# Altium schematic sheet 2_usb_debug.SchDoc(2_usb_debug)
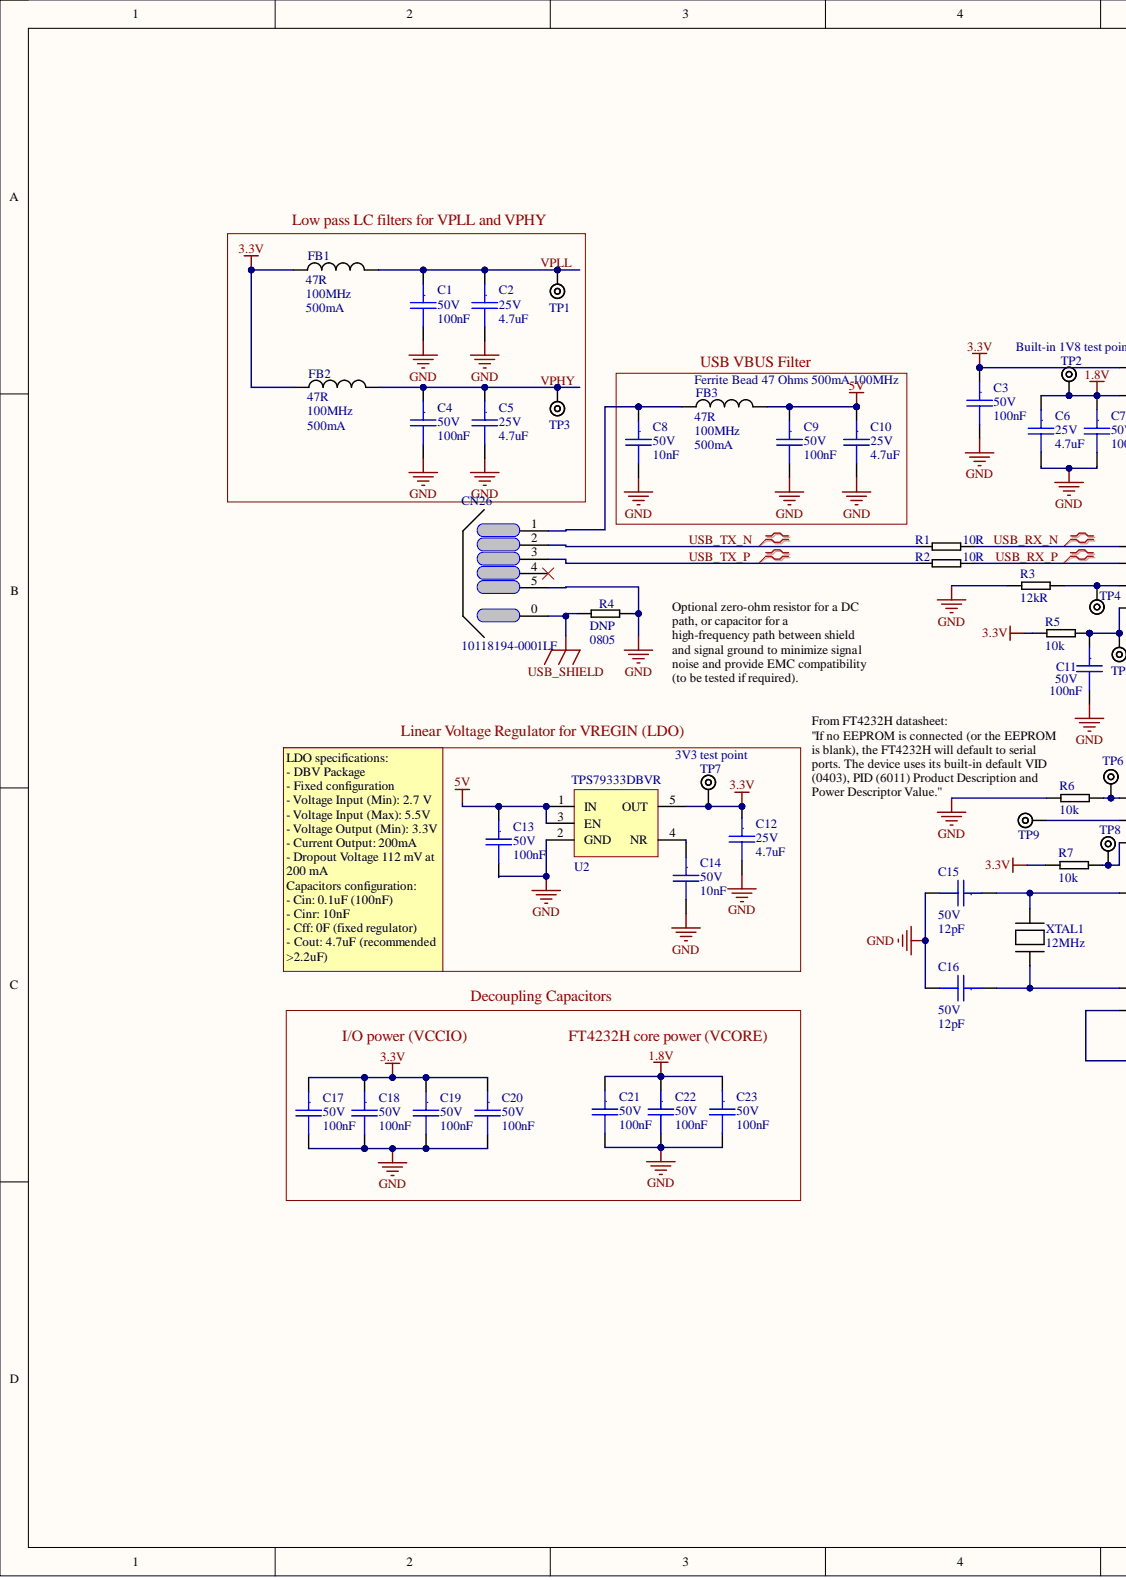
[diagram p0: 2_usb_debug.SchDoc, left]
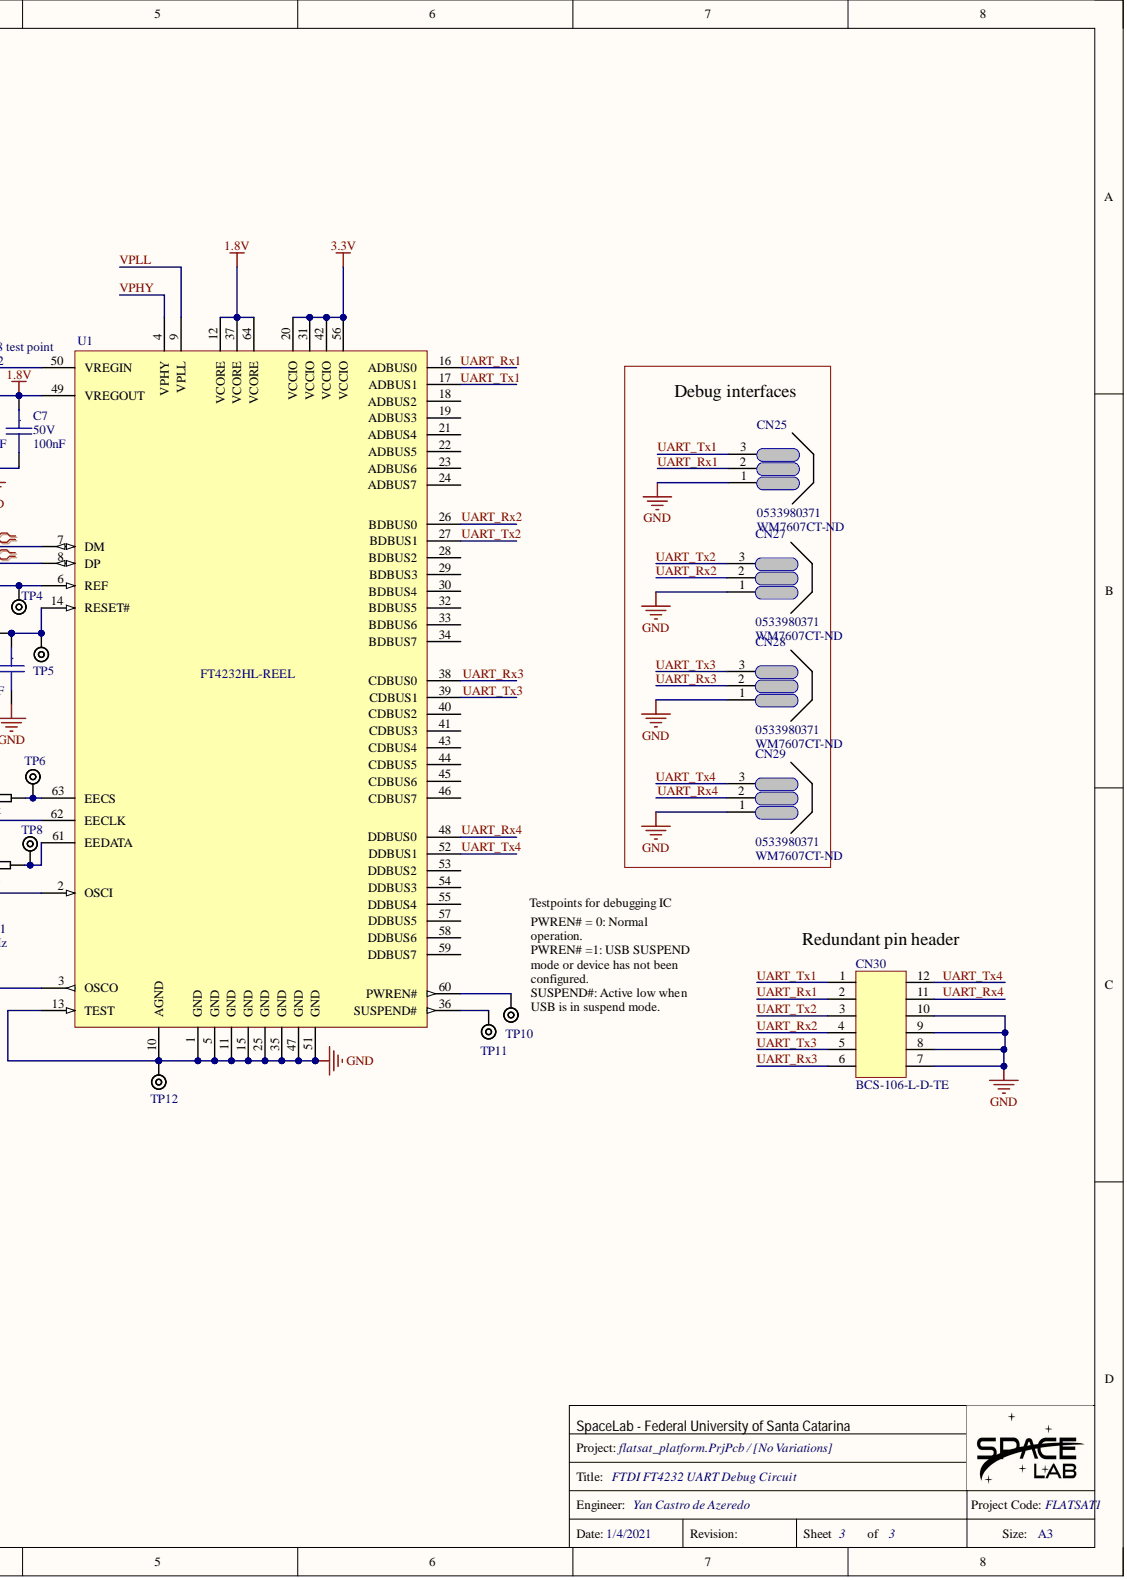
[diagram p1: 2_usb_debug.SchDoc, right]

## Parts
C1  Capacitance: 100nF  Voltage: 50V  [C0603_MD]  (hidden comment: 0.1 uF, 0603 (1608 metric))
C2  Capacitance: 4.7uF  Voltage: 25V  [C0805_MD]  (hidden comment: 4.7 uF, 0802 (2012 metric))
C3  Capacitance: 100nF  Voltage: 50V  [C0603_MD]  (hidden comment: 0.1 uF, 0603 (1608 metric))
C4  Capacitance: 100nF  Voltage: 50V  [C0603_MD]  (hidden comment: 0.1 uF, 0603 (1608 metric))
C5  Capacitance: 4.7uF  Voltage: 25V  [C0805_MD]  (hidden comment: 4.7 uF, 0802 (2012 metric))
C6  Capacitance: 4.7uF  Voltage: 25V  [C0805_MD]  (hidden comment: 4.7 uF, 0802 (2012 metric))
C7  Capacitance: 100nF  Voltage: 50V  [C0603_MD]  (hidden comment: 0.1 uF, 0603 (1608 metric))
C8  Capacitance: 10nF  Voltage: 50V  [C0805_MD]  (hidden comment: 10000 pF, 0805 (2012 metric))
C9  Capacitance: 100nF  Voltage: 50V  [C0603_MD]  (hidden comment: 0.1 uF, 0603 (1608 metric))
C10  Capacitance: 4.7uF  Voltage: 25V  [C0805_MD]  (hidden comment: 4.7 uF, 0802 (2012 metric))
C11  Capacitance: 100nF  Voltage: 50V  [C0603_MD]  (hidden comment: 0.1 uF, 0603 (1608 metric))
C12  Capacitance: 4.7uF  Voltage: 25V  [C0805_MD]  (hidden comment: 4.7 uF, 0802 (2012 metric))
C13  Capacitance: 100nF  Voltage: 50V  [C0603_MD]  (hidden comment: 0.1 uF, 0603 (1608 metric))
C14  Capacitance: 10nF  Voltage: 50V  [C0805_MD]  (hidden comment: 10000 pF, 0805 (2012 metric))
C15  Capacitance: 12pF  Voltage: 50V  [C0603_MD]  (hidden comment: 12 pF, 0603 (1608 metric))
C16  Capacitance: 12pF  Voltage: 50V  [C0603_MD]  (hidden comment: 12 pF, 0603 (1608 metric))
C17  Capacitance: 100nF  Voltage: 50V  [C0603_MD]  (hidden comment: 0.1 uF, 0603 (1608 metric))
C18  Capacitance: 100nF  Voltage: 50V  [C0603_MD]  (hidden comment: 0.1 uF, 0603 (1608 metric))
C19  Capacitance: 100nF  Voltage: 50V  [C0603_MD]  (hidden comment: 0.1 uF, 0603 (1608 metric))
C20  Capacitance: 100nF  Voltage: 50V  [C0603_MD]  (hidden comment: 0.1 uF, 0603 (1608 metric))
C21  Capacitance: 100nF  Voltage: 50V  [C0603_MD]  (hidden comment: 0.1 uF, 0603 (1608 metric))
C22  Capacitance: 100nF  Voltage: 50V  [C0603_MD]  (hidden comment: 0.1 uF, 0603 (1608 metric))
C23  Capacitance: 100nF  Voltage: 50V  [C0603_MD]  (hidden comment: 0.1 uF, 0603 (1608 metric))
CN25  0533980371  [PICO BLADE 0533980371]
CN26  10118194-0001LF  [MICRO USB B 90GRAUS]
CN27  0533980371  Supplier Part Number 1: WM7607CT-ND  [PICO BLADE 0533980371]
CN28  0533980371  Supplier Part Number 1: WM7607CT-ND  [PICO BLADE 0533980371]
CN29  0533980371  Supplier Part Number 1: WM7607CT-ND  [PICO BLADE 0533980371]
CN30  BCS-106-L-D-TE  [BCS-106-L-D-TE]
FB1  Current Rating: 500mA  Impedance: 47R  Test Frequency: 100MHz  [L0603]  (hidden comment: Ferrite Bead 47 Ohms 500mA 100MHz)
FB2  Current Rating: 500mA  Impedance: 47R  Test Frequency: 100MHz  [L0603]  (hidden comment: Ferrite Bead 47 Ohms 500mA 100MHz)
FB3  Ferrite Bead 47 Ohms 500mA 100MHz  Core Material: Ferrite  Current Rating: 500mA  Impedance: 47R  Test Frequency: 100MHz  [L0603]
R1  Resistance: 10R  [R0603_MD]  (hidden comment: RES 10 ohms (0603))
R2  Resistance: 10R  [R0603_MD]  (hidden comment: RES 10 ohms (0603))
R3  Resistance: 12kR  [R0805_MD]  (hidden comment: RES 12K ohms (0805))
R4  DNP  Case Code (Imperial): 0805  [R0805_MD]
R5  value 10k  [R0805_MD]  (hidden comment: 10k ohms, 0805 (2012 metric))
R6  value 10k  [R0805_MD]  (hidden comment: 10k ohms, 0805 (2012 metric))
R7  value 10k  [R0805_MD]  (hidden comment: 10k ohms, 0805 (2012 metric))
TP1  DNP  [TEST POINT PTH]  (value not drawn)  (no BOM)
TP2  DNP  [TEST POINT PTH]  (value not drawn)  (no BOM)
TP3  DNP  [TEST POINT PTH]  (value not drawn)  (no BOM)
TP4  DNP  [TEST POINT PTH]  (value not drawn)  (no BOM)
TP5  DNP  [TEST POINT PTH]  (value not drawn)  (no BOM)
TP6  DNP  [TEST POINT PTH]  (value not drawn)  (no BOM)
TP7  DNP  [TEST POINT PTH]  (value not drawn)  (no BOM)
TP8  DNP  [TEST POINT PTH]  (value not drawn)  (no BOM)
TP9  DNP  [TEST POINT PTH]  (value not drawn)  (no BOM)
TP10  DNP  [TEST POINT PTH]  (value not drawn)  (no BOM)
TP11  DNP  [TEST POINT PTH]  (value not drawn)  (no BOM)
TP12  DNP  [TEST POINT PTH]  (value not drawn)  (no BOM)
U1  FT4232HL-REEL  [LQFP-64]
U2  TPS79333DBVR  [SOT23-5]
XTAL1  Frequency: 12MHz  [TXC 7A Series]  (hidden comment: 12.000MHz 12pF)

## Nets
1.8V: C6.1 C7.1 C21.1 C22.1 C23.1 TP2.1 U1.12(VCORE) U1.37(VCORE) U1.49(VREGOUT) U1.64(VCORE)
3.3V: C3.1 C12.1 C17.1 C18.1 C19.1 C20.1 FB1.1 FB2.1 R5.1 R7.1 TP7.1 U1.20(VCCIO) U1.31(VCCIO) U1.42(VCCIO) U1.50(VREGIN) U1.56(VCCIO) U2.5(OUT)
5V: C9.1 C10.1 C13.1 FB3.2 U2.1(IN) U2.3(EN)
GND: C1.2 C2.2 C3.2 C4.2 C5.2 C6.2 C7.2 C8.2 C9.2 C10.2 C11.2 C12.2 C13.2 C14.2 C15.2 C16.2 C17.2 C18.2 C19.2 C20.2 C21.2 C22.2 C23.2 CN25.1 CN26.5 CN27.1 CN28.1 CN29.1 CN30.8 CN30.9 CN30.10 R3.1 R4.2 R6.1 TP12.1 U1.1(GND) U1.5(GND) U1.10(AGND) U1.11(GND) U1.13(TEST) U1.15(GND) U1.25(GND) U1.35(GND) U1.47(GND) U1.51(GND) U2.2(GND)
USB_SHIELD: CN26.0 R4.1
UART_Rx1: CN25.2 CN30.2 U1.16(ADBUS0)
UART_Rx2: CN27.2 CN30.4 U1.26(BDBUS0)
UART_Rx3: CN28.2 CN30.6 U1.38(CDBUS0)
UART_Rx4: CN29.2 CN30.11 U1.48(DDBUS0)
UART_Tx1: CN25.3 CN30.1 U1.17(ADBUS1)
UART_Tx2: CN27.3 CN30.3 U1.27(BDBUS1)
UART_Tx3: CN28.3 CN30.5 U1.39(CDBUS1)
UART_Tx4: CN29.3 CN30.12 U1.52(DDBUS1)
USB_RX_N: R1.2 U1.7(DM)
USB_TX_N: CN26.2 R1.1
USB_TX_P: CN26.3 R2.1
VPHY: C4.1 C5.1 FB2.2 TP3.1 U1.4(VPHY)
VPLL: C1.1 C2.1 FB1.2 TP1.1 U1.9(VPLL)
NetC8_1: C8.1 CN26.1 FB3.1
NetC11_1: C11.1 R5.2 TP5.1 U1.14(RESET#)
NetC14_1: C14.1 U2.4(NR)
NetC15_1: C15.1 U1.2(OSCI) XTAL1.1
NetC16_1: C16.1 U1.3(OSCO) XTAL1.2
NetR2_2: R2.2 U1.8(DP)
NetR3_2: R3.2 TP4.1 U1.6(REF)
NetR6_2: R6.2 TP6.1 U1.63(EECS)
NetR7_2: R7.2 TP8.1 U1.61(EEDATA)
NetTP9_1: TP9.1 U1.62(EECLK)
NetTP10_1: TP10.1 U1.60(PWREN#)
NetTP11_1: TP11.1 U1.36(SUSPEND#)
USB_RX_P:

Not connected: CN26 4; CN30 7; U1 18(ADBUS2) 19(ADBUS3) 21(ADBUS4) 22(ADBUS5) 23(ADBUS6) 24(ADBUS7) 28(BDBUS2) 29(BDBUS3) 30(BDBUS4) 32(BDBUS5) 33(BDBUS6) 34(BDBUS7) 40(CDBUS2) 41(CDBUS3) 43(CDBUS4) 44(CDBUS5) 45(CDBUS6) 46(CDBUS7) 53(DDBUS2) 54(DDBUS3) 55(DDBUS4) 57(DDBUS5) 58(DDBUS6) 59(DDBUS7)

## Library data of the parts
C1 C3 C4 C7 C9 C11 C13 C17-C23 = C1608X7R1H104K080AA: TDK - C1608X7R1H104K080AA - SMD Multilayer Ceramic Capacitor, 0.1 µF, 50 V, 0603 [1608 Metric], ± 10%, X7R, C Series; Case Code (Imperial): 0603; Case Code (Metric): 1608; Case/Package: 0603; Component Kind: Standard; ComponentLink1Description: https://product.tdk.com/info/en/catalog/spec/mlccspec_commercial_general_midvoltage_en.pdf?src-supplier=Digi-Key; ComponentLink1URL: https://product.tdk.com/info/en/catalog/spec/mlccspec_commercial_general_midvoltage_en.pdf?src-supplier=Digi-Key; ComponentLink2Description: http://uk.rs-online.com/webdocs/13d1/0900766b813d1103.pdf?src-supplier=RSComponents; ComponentLink2URL: http://uk.rs-online.com/webdocs/13d1/0900766b813d1103.pdf?src-supplier=RSComponents; ComponentLink3Description: http://www.tdk.co.jp/tefe02/e412_c.pdf?src-supplier=Avnet+Europe; ComponentLink3URL: http://www.tdk.co.jp/tefe02/e412_c.pdf?src-supplier=Avnet+Europe; ComponentLink4Description: http://www.farnell.com/datasheets/2792994.pdf?src-supplier=Farnell; ComponentLink4URL: http://www.farnell.com/datasheets/2792994.pdf?src-supplier=Farnell; ComponentLink5Description: http://www.farnell.com/datasheets/1744655.pdf?src-supplier=Element14; ComponentLink5URL: http://www.farnell.com/datasheets/1744655.pdf?src-supplier=Element14; Composition: Ceramic; Depth: 0.8mm; Dielectric: X7R; Dielectric Material: Ceramic; Height: 0.8mm; Lead Free: Lead Free; Length: 1.6mm; Manufacturer: TDK; Manufacturer Part Number: C1608X7R1H104K080AA; Max Operating Temperature: 125°C; Min Operating Temperature: -55°C; Mount: Surface Mount; Number of Pins: 2; Package Quantity: 1; Packaging: Tape and Reel; Pricing 1: 1=0.12, 10=0.088, 100=0.0413, 500=0.02896, 1000=0.02397 (USD); Pricing 2: 1=0.12, 10=0.088, 100=0.041, 500=0.029, 1000=0.024, 4000=0.017, 8000=0.016, 24000=0.013, 48000=0.011 (USD); Radiation Hardening: No; REACH SVHC: No SVHC; Stock 1: 513759; Stock 2: 34512; Supplier 1: Digi-Key; Supplier 2: Mouser; Supplier Part Number 1: 445-1314-1-ND; Supplier Part Number 2: 810-C1608X7R1H104K; Termination: SMD/SMT; Thickness: 0.037inch; Tolerance: 10%; Voltage Rating: 50V; Voltage Rating (DC): 50V; Weight: 7.1E-05oz; Width: 0.8mm; Library Name: flatsat_platform.SchLib
C2 C5 C6 C10 C12 = C2012X7R1E475K125AB: Ceramic Capacitor SMD Multilayer, 0805 [2012 Metric], 4.7 uF, 25 V,  10%, X7R, C Series; Manufacturer: TDK; Case Code (Imperial): 0805; Case Code (Metric): 2012; Case/Package: 0805; Component Kind: Standard; ComponentLink1Description: http://www.mouser.com/ds/2/400/lcc_commercial_general_en-837201.pdf?src-supplier=Mouser; ComponentLink1URL: http://www.mouser.com/ds/2/400/lcc_commercial_general_en-837201.pdf?src-supplier=Mouser; ComponentLink2Description: https://product.tdk.com/info/en/catalog/spec/mlccspec_commercial_general_midvoltage_en.pdf?src-supplier=Digi-Key; ComponentLink2URL: https://product.tdk.com/info/en/catalog/spec/mlccspec_commercial_general_midvoltage_en.pdf?src-supplier=Digi-Key; ComponentLink3Description: http://www.farnell.com/datasheets/1796654.pdf?src-supplier=Newark; ComponentLink3URL: http://www.farnell.com/datasheets/1796654.pdf?src-supplier=Newark; ComponentLink4Description: http://www.tdk.co.jp/tefe02/e412_c.pdf?src-supplier=Avnet+Europe; ComponentLink4URL: http://www.tdk.co.jp/tefe02/e412_c.pdf?src-supplier=Avnet+Europe; Composition: Ceramic; Depth: 1.25mm; Dielectric: X7R; Dielectric Material: Ceramic; Height: 1.25mm; Lead Free: Lead Free; Length: 2mm; Manufacturer Part Number: C2012X7R1E475K125AB; Max Operating Temperature: 125°C; Min Operating Temperature: -55°C; Mount: Surface Mount; Package Quantity: 2000; Packaging: Tape and Reel; Pricing 1: 1=0.48, 10=0.339, 100=0.223, 500=0.16518, 1000=0.1404 (USD); Pricing 2: 1=0.54, 10=0.382, 100=0.251, 500=0.186, 1000=0.158, 2000=0.121 (USD); Radiation Hardening: No; REACH SVHC: No SVHC; Stock 1: 196988; Stock 2: 141636; Supplier 1: Digi-Key; Supplier 2: Mouser; Supplier Part Number 1: 445-5972-1-ND; Supplier Part Number 2: 810-C2012X7R1E475K; Termination: SMD/SMT; Thickness: 0.057inch; Tolerance: 10%; Voltage Rating: 25V; Voltage Rating (DC): 25V; Weight: 0.000194oz; Width: 0.049inch; Library Name: flatsat_platform.SchLib
C8 C14 = 08055C103KAT4A: AVX - 08055C103KAT4A - SMD Multilayer Ceramic Capacitor, 10000 pF, 50 V, 0805 [2012 Metric], &#177; 10%, X7R; Manufacturer: Kyocera AVX; Case Code (Imperial): 0805; Case Code (Metric): 2012; Case/Package: 0805; Component Kind: Standard; ComponentLink1Description: http://www.mouser.com/ds/2/40/X7RDielectric-777024.pdf?src-supplier=Mouser; ComponentLink1URL: http://www.mouser.com/ds/2/40/X7RDielectric-777024.pdf?src-supplier=Mouser; ComponentLink2Description: http://www.avx.com/docs/Catalogs/cx7r.pdf?src-supplier=Future+Electronics; ComponentLink2URL: http://www.avx.com/docs/Catalogs/cx7r.pdf?src-supplier=Future+Electronics; Depth: 1.25mm; Dielectric: X7R; Height: 0.94mm; Lead Free: Lead Free; Length: 2.01mm; Manufacturer Part Number: 08055C103KAT4A; Max Operating Temperature: 125°C; Min Operating Temperature: -55°C; Mount: Surface Mount; Number of Pins: 2; Packaging: Tape and Reel; Pricing 1: 1=0.13, 10=0.094, 100=0.044, 500=0.03086, 1000=0.02555, 2500=0.02378, 5000=0.02176 (USD); Pricing 2: 1=0.12, 10=0.085, 100=0.04, 500=0.028, 1000=0.023, 2500=0.018 (USD); Radiation Hardening: No; Stock 1: 515489; Stock 2: 88428; Supplier 1: Digi-Key; Supplier 2: Mouser; Supplier Part Number 1: 478-10687-1-ND; Supplier Part Number 2: 581-08055C103KAT4A; Termination: SMD/SMT; Tolerance: 10%; Voltage Rating: 50V; Voltage Rating (DC): 50V; Weight: 0.000194oz; Width: 1.25mm; Library Name: flatsat_platform.SchLib
C15 C16 = CL10C120JB8NNNC: Cap Ceramic 12pF 50VDC C0G 5% SMD 0603 Paper T/R; Manufacturer: Samsung; Temperature Coefficient: 300ppm/°C; Case Code (Imperial): 0603; Case Code (Metric): 1608; Case/Package: 0603; Component Kind: Standard; ComponentLink1Description: https://media.digikey.com/pdf/Data%20Sheets/Samsung%20PDFs/CL_Series_MLCC_ds.pdf?src-supplier=Digi-Key; ComponentLink1URL: https://media.digikey.com/pdf/Data%20Sheets/Samsung%20PDFs/CL_Series_MLCC_ds.pdf?src-supplier=Digi-Key; ComponentLink2Description: https://www.verical.com/server-webapp/html/getDatasheet?partID=640658&src-supplier=Verical; ComponentLink2URL: https://www.verical.com/server-webapp/html/getDatasheet?partID=640658&src-supplier=Verical; ComponentLink3Description: https://produktinfo.conrad.com/datenblaetter/450000-474999/457317-da-01-en-VS_KONDENSATOR_0603.pdf?src-supplier=Conrad; ComponentLink3URL: https://produktinfo.conrad.com/datenblaetter/450000-474999/457317-da-01-en-VS_KONDENSATOR_0603.pdf?src-supplier=Conrad; Contact Plating: Tin; Depth: 0.8mm; Dielectric: C0G; Height: 0.8mm; Lead Free: Lead Free; Length: 1.6mm; Manufacturer Part Number: CL10C120JB8NNNC; Material: Ceramic; Max Operating Temperature: 125°C; Min Operating Temperature: -55°C; Mount: Surface Mount; Package Quantity: 1; Packaging: Tape and Reel; Pricing 1: 1=0.1, 10=0.045, 100=0.0201, 500=0.01436, 1000=0.01128 (USD); Radiation Hardening: No; Stock 1: 64675; Supplier 1: Digi-Key; Supplier Part Number 1: 1276-1254-1-ND; Thickness: 0.035inch; Tolerance: 5%; Voltage Rating: 50V; Voltage Rating (DC): 50V; Width: 0.031inch; Library Name: flatsat_platform.SchLib
CN25 CN27-CN29 = 0533980371: Conn Wire to Board HDR 3 POS 1.25mm Solder ST SMD Embossed T/R; Manufacturer: Molex; Manufacturer Part Number: 53398-0371; Body Plating: Tin; Contact Resistance: 20mR; Current Rating: 1A; Gender: Male; Glow Wire Compliant: Non-compliant; Insulation Resistance: 100MR; N of Positions: 3; Voltage Rating: 125V; Category: Headers and Wire Housings; Color: Natural; Component Kind: Standard; ComponentLink1Description: https://datasheet.ciiva.com/30064/225330533980371-pcb-headers-30064544.pdf?src-supplier=Onlinecomponents.com; ComponentLink1URL: https://datasheet.ciiva.com/30064/225330533980371-pcb-headers-30064544.pdf?src-supplier=Onlinecomponents.com; ComponentLink2Description: https://datasheet.ciiva.com/9468/0533980371-pcb-headers-9468515.pdf?src-supplier=Avnet; ComponentLink2URL: https://datasheet.ciiva.com/9468/0533980371-pcb-headers-9468515.pdf?src-supplier=Avnet; ComponentLink3Description: https://datasheet.ciiva.com/11748/0900766b8078fc93-11748675.pdf?src-supplier=RSComponents; ComponentLink3URL: https://datasheet.ciiva.com/11748/0900766b8078fc93-11748675.pdf?src-supplier=RSComponents; ComponentLink4Description: https://datasheet.ciiva.com/19465/1302182-19465258.pdf?src-supplier=Jameco; ComponentLink4URL: https://datasheet.ciiva.com/19465/1302182-19465258.pdf?src-supplier=Jameco; ComponentLink5Description: https://datasheet.ciiva.com/5147/0533980371-pcb-headers-146663-5147630.pdf?src-supplier=Mouser; ComponentLink5URL: https://datasheet.ciiva.com/5147/0533980371-pcb-headers-146663-5147630.pdf?src-supplier=Mouser; ComponentLink6Description: https://datasheet.ciiva.com/16972/70091287-16972277.pdf?src-supplier=Allied+Electronics; ComponentLink6URL: https://datasheet.ciiva.com/16972/70091287-16972277.pdf?src-supplier=Allied+Electronics; ComponentLink7Description: https://datasheet.ciiva.com/7810/1788579-7810395.pdf?src-supplier=Element14; ComponentLink7URL: https://datasheet.ciiva.com/7810/1788579-7810395.pdf?src-supplier=Element14; ComponentLink8Description: https://datasheet.ciiva.com/16496/0533980371-pcb-headers-16496972.pdf?src-supplier=Avnet+Asia; ComponentLink8URL: https://datasheet.ciiva.com/16496/0533980371-pcb-headers-16496972.pdf?src-supplier=Avnet+Asia; ComponentLink9Description: https://datasheet.ciiva.com/16658/0533980371-pcb-headers-16658430.pdf?src-supplier=Avnet+Europe; ComponentLink9URL: https://datasheet.ciiva.com/16658/0533980371-pcb-headers-16658430.pdf?src-supplier=Avnet+Europe; Contact Gender: Male; Contact Material: Bronze,Tin; Contact Plating: Tin; Flammability Rating: UL94 V-0; Housing Color: Natural; Housing Material: Nylon; Lead Free: Lead Free; Lead Pitch: 1.25mm; Max Operating Temperature: 85°C; Min Operating Temperature: -40°C; Mount: Surface Mount; Mount Type: SMD; Number of Circuits: 3; Number of Contacts: 3; Number of Positions: 3; Number of Rows: 1; Orientation: Vertical; Package Quantity: 1000; Packaging: Tape and Reel; Pitch: 1.25mm; Pricing 1: 1=0.64000, 10=0.54400, 100=0.42320 (USD); Radiation Hardening: No; REACH SVHC: Unknown; RoHS: Yes; Stock 1: 192; Supplier 1: Digi-Key; Termination: SMD/SMT; Value: 0533980371; Voltage Rating (AC): 125V; Voltage Rating (DC): 125V; Working Voltage: 125V; Library Name: flatsat_platform.SchLib
  CN25: Supplier Part Number 1: WM7607CT-ND
CN26 = 10118194-0001LF: CONN USB MICRO B RECPT SMT R/A; Manufacturer: FCI; Connector Type: USB,Receptacle; Current Rating: 1.8A; Gender: Receptacle; Insulation Resistance: 1GR; Max Voltage Rating (AC): 100V; N of Positions: 5; Number of Ports: 1; Pitch: 0.65mm; Category: USB Connectors; Color: Black; Component Kind: Standard; ComponentLink1Description: https://datasheet.ciiva.com/4598/10118194ac-197217-4598807.pdf?src-supplier=Mouser; ComponentLink1URL: https://datasheet.ciiva.com/4598/10118194ac-197217-4598807.pdf?src-supplier=Mouser; ComponentLink2Description: https://datasheet.ciiva.com/26945/getdatasheetpartid-418619-26945695.pdf?src-supplier=Verical; ComponentLink2URL: https://datasheet.ciiva.com/26945/getdatasheetpartid-418619-26945695.pdf?src-supplier=Verical; ComponentLink3Description: https://datasheet.ciiva.com/851/10118194-851110.pdf?src-supplier=Digi-Key; ComponentLink3URL: https://datasheet.ciiva.com/851/10118194-851110.pdf?src-supplier=Digi-Key; ComponentLink4Description: https://datasheet.ciiva.com/851/10118194-851111.pdf?src-supplier=Digi-Key; ComponentLink4URL: https://datasheet.ciiva.com/851/10118194-851111.pdf?src-supplier=Digi-Key; Contact Material: Copper Alloy; Contact Plating: Gold; Depth: 5mm; Features: Shielded; Flammability Rating: UL94 V-0; Housing Material: Polymer; Lead Free: Lead Free; Length: 8.05mm; Manufacturer Part Number: 10118194-0001LF; Max Operating Temperature: 85°C; Min Operating Temperature: -55°C; Mount: Surface Mount,Horizontal; Mount Type: PTH; Number of Contacts: 5; Number of Terminals/Pins: 5; Orientation: Right Angle; Package Quantity: 9600; Packaging: Tape and Reel; Pricing 4: 1=0.22400, 25=0.22400, 100=0.22400, 250=0.20500, 500=0.20500 (USD); Radiation Hardening: No; RoHS: Yes; Stock 4: 39603; Supplier 1: Digi-Key; Supplier 2: Farnell; Supplier 3: Mouser; Supplier 4: Newark; Supplier Part Number 1: 609-4618-1-ND; Supplier Part Number 2: 1645325; Supplier Part Number 3: 649-10118194-0001LF; Supplier Part Number 4: 67T2260; Termination: Solder; Value: 10118194-0001LF; Library Name: flatsat_platform.SchLib
CN30 = BCS-106-L-D-TE: CONN RCPT 12POS 0.1 GOLD PCB; Manufacturer: Samtec; Connector Type: Receptacle; Contact Resistance: 10mR; Max Current Rating: 5.9A; Max Voltage Rating (AC): 475V; Number of Contacts: 12; Pitch: 2.54mm; Row Spacing: 0.1inch; Category: Connectors; Color: Black; Component Kind: Standard; ComponentLink1Description: https://datasheet.ciiva.com/16706/bcs-16706444.pdf?src-supplier=Avnet+Europe; ComponentLink1URL: https://datasheet.ciiva.com/16706/bcs-16706444.pdf?src-supplier=Avnet+Europe; ComponentLink2Description: https://datasheet.ciiva.com/413/bcs-1xx-xxx-x-xx-xxx-mkt-413758.pdf?src-supplier=Digi-Key; ComponentLink2URL: https://datasheet.ciiva.com/413/bcs-1xx-xxx-x-xx-xxx-mkt-413758.pdf?src-supplier=Digi-Key; Contact Material: Bronze; Contact Plating: Gold; Depth: 5.08mm; Gender: Receptacle; Housing Color: Black; Housing Material: Polymer; Lead Free: Lead Free; Length: 15.24mm; Manufacturer Part Number: BCS-106-L-D-TE; Max Operating Temperature: 125°C; Min Operating Temperature: -55°C; Mount: Through Hole; Number of Positions: 12; Number of Rows: 2; Orientation: Vertical; Packaging: Rail/Tube; Pricing 1: 1=1.77000, 10=1.60200, 25=1.50360, 50=1.43820, 100=1.37290, 250=1.24216, 500=1.14408 (USD); Radiation Hardening: No; REACH SVHC: No SVHC; RoHS: Yes; Stock 1: 7411; Supplier 1: Digi-Key; Supplier Part Number 1: SAM1008-06-ND; Termination: Solder; Width: 5.08mm; Library Name: flatsat_platform.SchLib
FB1-FB3 = 742792608: WURTH ELEKTRONIK   742792608   FERRITE BEAD, 0.1 OHM, 0.5A, 0603; Manufacturer: Wurth Electronics; DC Resistance (DCR): 100mR; Inductance: 1H; Number of Lines: 1; Tolerance: 25%; Case Code (Imperial): 0603; Case Code (Metric): 1608; Case/Package: SMD/SMT; Component Kind: Standard; ComponentLink1Description: http://katalog.we-online.de/pbs/datasheet/742792608.pdf?src-supplier=Digi-Key; ComponentLink1URL: http://katalog.we-online.de/pbs/datasheet/742792608.pdf?src-supplier=Digi-Key; ComponentLink2Description: http://www.farnell.com/datasheets/2290671.pdf?src-supplier=Farnell; ComponentLink2URL: http://www.farnell.com/datasheets/2290671.pdf?src-supplier=Farnell; ComponentLink3Description: http://documents.rs-components.com/techlib://itc_doclist_GB.htm?stockno=6693986&src-supplier=RSComponents; ComponentLink3URL: http://documents.rs-components.com/techlib://itc_doclist_GB.htm?stockno=6693986&src-supplier=RSComponents; ComponentLink4Description: http://www.farnell.com/datasheets/1696033.pdf?src-supplier=Element14; ComponentLink4URL: http://www.farnell.com/datasheets/1696033.pdf?src-supplier=Element14; ComponentLink5Description: http://www.mouser.com/ds/2/445/742792608-538481.pdf?src-supplier=Mouser; ComponentLink5URL: http://www.mouser.com/ds/2/445/742792608-538481.pdf?src-supplier=Mouser; ComponentLink6Description: http://www.farnell.com/datasheets/2290671.pdf?src-supplier=Newark; ComponentLink6URL: http://www.farnell.com/datasheets/2290671.pdf?src-supplier=Newark; Depth: 0.8mm; Height: 0.8mm; Lead Free: Lead Free; Length: 1.6mm; Manufacturer Part Number: 742792608; Material: Ferrite; Max Current Rating: 500mA; Max DC Current: 500mA; Max Operating Temperature: 125°C; Min Operating Temperature: -55°C; Mount: Surface Mount; Package Quantity: 1; Packaging: Tape and Reel; Pricing 1: 1=0.17, 50=0.168, 100=0.155, 500=0.148, 1000=0.141, 2000=0.134 (USD); Pricing 2: 1=0.18, 10=0.172, 25=0.172, 50=0.168, 100=0.155, 250=0.155 (USD); REACH SVHC: Unknown; Resistance: 100mR; Stock 1: 969; Stock 2: 6414; Supplier 1: Digi-Key; Supplier 2: Mouser; Supplier Part Number 1: 732-1596-1-ND; Supplier Part Number 2: 710-742792608; Termination: SMD/SMT; Weight: 0.000141oz; Width: 0.8mm; Library Name: flatsat_platform.SchLib
  FB1 FB2: Core Material: Ferrite
R1 R2 = RC0603FR-0710RL: YAGEO (PHYCOMP) - RC0603FR-0710RL - RES, THICK FILM, 10R, 1%, 0.1W, 0603; Power Rating: 100mW; Temperature Coefficient: 200ppm/°C; Voltage Rating: 50V; Case Code (Imperial): 0603; Case Code (Metric): 1608; Case/Package: 0603; Component Kind: Standard; ComponentLink1Description: http://www.farnell.com/datasheets/1868787.pdf?src-supplier=Element14; ComponentLink1URL: http://www.farnell.com/datasheets/1868787.pdf?src-supplier=Element14; ComponentLink2Description: http://www.yageo.com/documents/recent/PYu-RC0603_51_RoHS_L_4.pdf?src-supplier=Avnet; ComponentLink2URL: http://www.yageo.com/documents/recent/PYu-RC0603_51_RoHS_L_4.pdf?src-supplier=Avnet; ComponentLink3Description: http://documents.rs-components.com/techlib://itc_doclist_GB.htm?stockno=5048984&src-supplier=RSComponents; ComponentLink3URL: http://documents.rs-components.com/techlib://itc_doclist_GB.htm?stockno=5048984&src-supplier=RSComponents; ComponentLink4Description: http://www.yageo.com/documents/recent/PYu-RC0603_51_RoHS_L_4.pdf?src-supplier=Avnet+Europe; ComponentLink4URL: http://www.yageo.com/documents/recent/PYu-RC0603_51_RoHS_L_4.pdf?src-supplier=Avnet+Europe; Composition: Thick Film; Contact Plating: Tin,Matte; Depth: 0.8mm; Height: 0.45mm; Lead Free: Lead Free; Length: 1.6mm; Manufacturer: Yageo; Manufacturer Part Number: RC0603FR-0710RL; Max Operating Temperature: 155°C; Military Standard: Not; Min Operating Temperature: -55°C; Number of Pins: 2; Packaging: Tape and Reel; Pricing 1: 1=0.1, 10=0.046, 100=0.0187, 1000=0.0084, 2500=0.00729 (USD); Pricing 2: 1=0.15, 10=0.036, 100=0.012, 1000=0.009, 5000=0.006, 10000=0.006 (USD); Radiation Hardening: No; REACH SVHC: No SVHC; Stock 1: 9251104; Stock 2: 267888; Supplier 1: Digi-Key; Supplier 2: Mouser; Supplier Part Number 1: 311-10.0HRCT-ND; Supplier Part Number 2: 603-RC0603FR-0710RL; Termination: SMD/SMT; Tolerance: 1%; Voltage Rating (DC): 50V; Width: 0.8mm; Library Name: flatsat_platform.SchLib
R3 = RMCF0805FT12K0: Resistor, SMT, 0805, 12K Ohm, +/-1%, +/-100ppm, Thk Film, T/R Marked; Manufacturer: Stackpole Electronics; Max Power Dissipation: 125mW; Temperature Coefficient: 100ppm/°C; Tolerance: 1%; Case Code (Imperial): 0805; Case Code (Metric): 2012; Case/Package: 0805; Component Kind: Standard; ComponentLink1Description: https://www.seielect.com/catalog/SEI-RMCF_RMCP.pdf?src-supplier=Avnet; ComponentLink1URL: https://www.seielect.com/catalog/SEI-RMCF_RMCP.pdf?src-supplier=Avnet; ComponentLink2Description: https://www.seielect.com/Catalog/SEI-RMCF_RMCP.pdf?src-supplier=Digi-Key; ComponentLink2URL: https://www.seielect.com/Catalog/SEI-RMCF_RMCP.pdf?src-supplier=Digi-Key; ComponentLink3Description: https://www.seielect.com/catalog/SEI-Packaging.pdf?src-supplier=Digi-Key; ComponentLink3URL: https://www.seielect.com/catalog/SEI-Packaging.pdf?src-supplier=Digi-Key; ComponentLink4Description: http://seielect.com/Catalog/SEI-RMCF_RMCP.pdf?src-supplier=Future+Electronics; ComponentLink4URL: http://seielect.com/Catalog/SEI-RMCF_RMCP.pdf?src-supplier=Future+Electronics; ComponentLink5Description: http://components.arrow.com/part/dataview/40964023S7542466N1174?src-supplier=Arrow; ComponentLink5URL: http://components.arrow.com/part/dataview/40964023S7542466N1174?src-supplier=Arrow; Composition: Thick Film; Contact Plating: Tin,Matte; Features: Automotive AEC-Q200; Height: 0.026inch; Lead Free: Lead Free; Length: 0.079inch; Manufacturer Part Number: RMCF0805FT12K0; Max Operating Temperature: 155°C; Min Operating Temperature: -55°C; Mount: Surface Mount; Number of Terminations: 2; Packaging: Tape and Reel; Power Rating: 125mW; Pricing 1: 1=0.1, 10=0.026, 100=0.0104, 1000=0.00466, 2500=0.00405 (USD); Radiation Hardening: No; Stock 1: 30304; Supplier 1: Digi-Key; Supplier Part Number 1: RMCF0805FT12K0CT-ND; Width: 0.049inch; Library Name: flatsat_platform.SchLib
R4 = CRCW08050000Z0EA: VISHAY - CRCW08050000Z0EA - SMD Chip Resistor, Jumper, 0805 [2012 Metric], 0 ohm, CRCW e3 Series, 150 V, Thick Film, 125 mW; Max Power Dissipation: 125mW; Resistance: 0mR; Temperature Coefficient: 100ppm/°C; Tolerance: 1%; Voltage Rating: 150V; Case Code (Metric): 2012; Case/Package: 0805; Component Kind: Standard; ComponentLink1Description: http://www.vishay.com/docs/20035/dcrcwe3.pdf?src-supplier=Avnet+Europe; ComponentLink1URL: http://www.vishay.com/docs/20035/dcrcwe3.pdf?src-supplier=Avnet+Europe; ComponentLink2Description: http://www.vishay.com/docs/20035/dcrcwe3.pdf?src-supplier=Distrelec; ComponentLink2URL: http://www.vishay.com/docs/20035/dcrcwe3.pdf?src-supplier=Distrelec; ComponentLink3Description: http://www.vishay.com/docs/20035/dcrcwe3.pdf?src-supplier=Mouser; ComponentLink3URL: http://www.vishay.com/docs/20035/dcrcwe3.pdf?src-supplier=Mouser; ComponentLink4Description: http://uk.rs-online.com/webdocs/0d98/0900766b80d98b26.pdf?src-supplier=RSComponents; ComponentLink4URL: http://uk.rs-online.com/webdocs/0d98/0900766b80d98b26.pdf?src-supplier=RSComponents; ComponentLink5Description: http://www.vishay.com/docs/20035/dcrcwe3.pdf?src-supplier=Future+Electronics; ComponentLink5URL: http://www.vishay.com/docs/20035/dcrcwe3.pdf?src-supplier=Future+Electronics; ComponentLink6Description: http://www.vishay.com/docs/20035/dcrcwe3.pdf?src-supplier=Allied+Electronics; ComponentLink6URL: http://www.vishay.com/docs/20035/dcrcwe3.pdf?src-supplier=Allied+Electronics; ComponentLink7Description: http://www.vishay.com/docs/20035/dcrcwe3.pdf?src-supplier=Digi-Key; ComponentLink7URL: http://www.vishay.com/docs/20035/dcrcwe3.pdf?src-supplier=Digi-Key; ComponentLink8Description: http://www.farnell.com/datasheets/2310790.pdf?src-supplier=Element14; ComponentLink8URL: http://www.farnell.com/datasheets/2310790.pdf?src-supplier=Element14; Composition: Thick Film; Contact Plating: Tin,Lead; Depth: 1.25mm; Features: Automotive AEC-Q200; Height: 0.45mm; Lead Free: Lead Free; Length: 2mm; Manufacturer: Vishay; Manufacturer Part Number: CRCW08050000Z0EA; Max Operating Temperature: 155°C; Military Standard: Not; Min Operating Temperature: -55°C; Number of Terminations: 2; Package Quantity: 1; Packaging: Tape and Reel; Power Rating: 125mW; Pricing 1: 1=0.1, 10=0.04, 25=0.0288, 50=0.022, 100=0.0162, 250=0.01236, 500=0.0099, 1000=0.00729 (USD); Pricing 2: 1=0.1, 10=0.024, 100=0.01, 1000=0.007, 5000=0.004, 10000=0.004 (USD); Radiation Hardening: No; REACH SVHC: Unknown; Stock 1: 487981; Stock 2: 496700; Supplier 1: Digi-Key; Supplier 2: Mouser; Supplier Part Number 1: 541-0.0ACT-ND; Supplier Part Number 2: 71-CRCW0805-0-E3; Termination: SMD/SMT; Voltage Rating (DC): 150V; Weight: 0.000194oz; Width: 1.25mm; Library Name: flatsat_platform.SchLib
R5-R7 = CRCW080510K0FKEA: RES 10.0K OHM 1/8W 1% 0805 SMD; Manufacturer: Vishay Dale; Power Rating: 125mW; Resistance: 10kR; Temperature Coefficient: 100ppm/°C; Voltage Rating: 150V; Case Code (Imperial): 0805; Case Code (Metric): 2012; Case/Package: 0805; Component Kind: Standard; ComponentLink1Description: http://www.mouser.com/ds/2/427/dcrcwe3-109170.pdf?src-supplier=Mouser; ComponentLink1URL: http://www.mouser.com/ds/2/427/dcrcwe3-109170.pdf?src-supplier=Mouser; ComponentLink2Description: http://uk.rs-online.com/webdocs/0d98/0900766b80d98b26.pdf?src-supplier=RSComponents; ComponentLink2URL: http://uk.rs-online.com/webdocs/0d98/0900766b80d98b26.pdf?src-supplier=RSComponents; ComponentLink3Description: http://www.alliedelec.com/m/d/0c934d6939ccefa68dbe0b96d821c8cf.pdf?src-supplier=Allied+Electronics; ComponentLink3URL: http://www.alliedelec.com/m/d/0c934d6939ccefa68dbe0b96d821c8cf.pdf?src-supplier=Allied+Electronics; ComponentLink4Description: http://www.vishay.com/docs/20035/dcrcwe3.pdf?src-supplier=Avnet+Asia; ComponentLink4URL: http://www.vishay.com/docs/20035/dcrcwe3.pdf?src-supplier=Avnet+Asia; ComponentLink5Description: http://www.vishay.com/docs/20035/dcrcwe3.pdf?src-supplier=Avnet+Europe; ComponentLink5URL: http://www.vishay.com/docs/20035/dcrcwe3.pdf?src-supplier=Avnet+Europe; ComponentLink6Description: http://www.farnell.com/datasheets/2310790.pdf?src-supplier=Element14; ComponentLink6URL: http://www.farnell.com/datasheets/2310790.pdf?src-supplier=Element14; ComponentLink7Description: http://www.vishay.com/docs/20035/dcrcwe3.pdf?src-supplier=Avnet; ComponentLink7URL: http://www.vishay.com/docs/20035/dcrcwe3.pdf?src-supplier=Avnet; ComponentLink8Description: http://www.vishay.com/docs/20035/dcrcwe3.pdf?src-supplier=Future+Electronics; ComponentLink8URL: http://www.vishay.com/docs/20035/dcrcwe3.pdf?src-supplier=Future+Electronics; Composition: Thick Film; Contact Plating: Tin; Depth: 1.25mm; Height: 0.45mm; Lead Free: Lead Free; Length: 2mm; Manufacturer Part Number: CRCW080510K0FKEA; Max Operating Temperature: 155°C; Military Standard: Not; Min Operating Temperature: -55°C; Mount Type: SMD; Package Quantity: 5000; Packaging: Tape and Reel; Power: 1/8W; Pricing 2: 1=0.1, 10=0.062, 100=0.025, 1000=0.01, 5000=0.007, 10000=0.006, 25000=0.005 (USD); Pricing 6: 1=0.1, 10=0.062, 100=0.0254, 1000=0.01142, 2500=0.00991 (USD); Radiation Hardening: No; REACH SVHC: Unknown; Stock 2: 101022; Stock 6: 1354479; Supplier 1: Newark; Supplier 2: Mouser; Supplier 3: Digi-Key; Supplier 4: Allied; Supplier 5: Farnell; Supplier 6: Digi-Key; Supplier Part Number 1: 52K9795; Supplier Part Number 2: 71-CRCW0805-10K-E3; Supplier Part Number 3: 541-10.0KCCT-ND; Supplier Part Number 4: 70201192; Supplier Part Number 5: 1632457; Supplier Part Number 6: 541-10.0KCDKR-ND; Termination: SMD/SMT; Tolerance: 1%; Voltage Rating (DC): 150V; Weight: 0.000194oz; Width: 1.25mm; Library Name: flatsat_platform.SchLib
TP1-TP12 = Test Point PTH: TEST POINT PAD; Library Name: flatsat_platform.SchLib
U1 = FT4232HL-REEL: FTDI - FT4232HL-REEL - USB-UART/MPSSE, 4232, QUAD, 64LQFP; Data Rate: 480Mbps; Dual Supply Voltage: 3.3V; ESD Protection: Yes; Interface: UART; Max Supply Voltage: 3.63V; Min Supply Voltage: 1.62V; Number of Channels: 1; Number of Transceivers: 4; Operating Supply Current: 70mA; Case/Package: LQFP; Component Kind: Standard; ComponentLink1Description: http://www.ftdichip.com/Support/Documents/AppNotes/AN_128_User_Guide_For_FT4232H_Factory%20test%20utility.pdf?src-supplier=Digi-Key; ComponentLink1URL: http://www.ftdichip.com/Support/Documents/AppNotes/AN_128_User_Guide_For_FT4232H_Factory%20test%20utility.pdf?src-supplier=Digi-Key; ComponentLink2Description: http://www.alliedelec.com/images/products/datasheets/bm/FTDI/70403916.pdf?src-supplier=Allied+Electronics; ComponentLink2URL: http://www.alliedelec.com/images/products/datasheets/bm/FTDI/70403916.pdf?src-supplier=Allied+Electronics; ComponentLink3Description: http://www.ftdichip.com/Support/Documents/DataSheets/ICs/DS_FT4232H.pdf?src-supplier=Future+Electronics; ComponentLink3URL: http://www.ftdichip.com/Support/Documents/DataSheets/ICs/DS_FT4232H.pdf?src-supplier=Future+Electronics; ComponentLink4Description: https://www.verical.com/server-webapp/html/getDatasheet?partID=643215&src-supplier=Verical; ComponentLink4URL: https://www.verical.com/server-webapp/html/getDatasheet?partID=643215&src-supplier=Verical; ComponentLink5Description: http://datasheet.iiic.cc/ftdi/FT4232HL-REEL.pdf?src-supplier=IIIC; ComponentLink5URL: http://datasheet.iiic.cc/ftdi/FT4232HL-REEL.pdf?src-supplier=IIIC; Height: 1.4mm; Lead Free: Lead Free; Length: 10.1mm; Manufacturer: FTDI; Manufacturer Part Number: FT4232HL-REEL; Max Operating Temperature: 85°C; Min Operating Temperature: -40°C; Mount: Surface Mount; Number of Pins: 64; Operating Supply Voltage: 3.3V; Package Quantity: 1000; Packaging: Tape and Reel; Pricing 1: 1=8.3, 10=8.09, 50=7.46, 100=7.14, 500=6.88006 (USD); Pricing 2: 1=8.3, 25=8.09, 50=7.46, 100=7.14, 500=6.88, 1000=6.08 (USD); Radiation Hardening: No; Stock 1: 18748; Stock 2: 21282; Supplier 1: Digi-Key; Supplier 2: Mouser; Supplier Part Number 1: 768-1026-1-ND; Supplier Part Number 2: 895-FT4232HL; Weight: 0.012088oz; Width: 10.1mm; Library Name: flatsat_platform.SchLib
U2 = TPS79333DBVR: TEXAS INSTRUMENTS - TPS79333DBVR - IC, V REG, LINEAR, 0.2A, SOT-23-5; Accuracy: 2%; Current Rating: 200mA; Dropout Voltage: 102mV; Input Bias Current: 170uA; Max Input Voltage: 5.5V; Max Output Voltage: 3.3V; Max Power Dissipation: 560mW; Min Current Limit: 285mA; Min Input Voltage: 2.7V; Number of Outputs: 1; Output Type: Fixed; Polarity: Positive; Quiescent Current: 200uA; Case/Package: SOT-23; Component Kind: Standard; ComponentLink1Description: http://www.ti.com/general/docs/lit/getliterature.tsp?genericPartNumber=TPS793&amp;&amp;fileType=pdf&src-supplier=Farnell; ComponentLink1URL: http://www.ti.com/general/docs/lit/getliterature.tsp?genericPartNumber=TPS793&amp;&amp;fileType=pdf&src-supplier=Farnell; ComponentLink2Description: http://www.jameco.com/Jameco/Products/ProdDS/1123416.pdf?src-supplier=Jameco; ComponentLink2URL: http://www.jameco.com/Jameco/Products/ProdDS/1123416.pdf?src-supplier=Jameco; Height: 1.15mm; Lead Free: Lead Free; Length: 2.9mm; Manufacturer: Texas Instruments; Manufacturer Part Number: TPS79333DBVR; Max Operating Temperature: 125°C; Max Output Current: 200mA; Max Supply Voltage: 5.5V; Min Operating Temperature: -40°C; Min Output Voltage: 3.3V; Min Supply Voltage: 2.7V; Nominal Output Voltage: 3.3V; Number of Pins: 5; Number of Regulators: 1; Output Current: 200mA; Output Voltage: 3.3V; Output Voltage Accuracy: 2%; Package Quantity: 1; Packaging: Tape and Reel; Power Dissipation: 560mW; Power Rating: 560mW; Pricing 1: 1=0.73, 10=0.64, 25=0.6016, 100=0.4559, 250=0.388, 500=0.3686, 1000=0.2813 (USD); Pricing 2: 1=0.72, 10=0.6, 100=0.379, 1000=0.289, 2000=0.282, 3000=0.252, 9000=0.242, 48000=0.233 (USD); Radiation Hardening: No; REACH SVHC: No SVHC; Stock 1: 21565; Stock 2: 31707; Supplier 1: Digi-Key; Supplier 2: Mouser; Supplier Part Number 1: 296-12961-1-ND; Supplier Part Number 2: 595-TPS79333DBVR; Termination: SMD/SMT; Width: 1.6mm; Library Name: flatsat_platform.SchLib
XTAL1 = AA-12.000MAGE-T: Crystals 12.000MHz 30ppm 12pF -40C to 85C; Manufacturer: TXC; ESR (Equivalent Series Resistance): 100R; Frequency Stability: 50ppm; Case/Package: SMD/SMT; Component Kind: Standard; ComponentLink1Description: http://www.txccrystal.com/images/pdf/number-crystal-automotive.pdf?src-supplier=Digi-Key; ComponentLink1URL: http://www.txccrystal.com/images/pdf/number-crystal-automotive.pdf?src-supplier=Digi-Key; ComponentLink2Description: http://www.farnell.com/datasheets/1811860.pdf?src-supplier=Element14; ComponentLink2URL: http://www.farnell.com/datasheets/1811860.pdf?src-supplier=Element14; Frequency Tolerance: 30ppm; Height: 0.053inch; Length: 0.197inch; Manufacturer Part Number: AA-12.000MAGE-T; Max Operating Temperature: 85°C; Min Operating Temperature: -40°C; Mount: Surface Mount; Number of Pins: 2; Package Quantity: 1000; Packaging: Tape and Reel; Pricing 1: 1=0.83, 10=0.734, 50=0.6858, 100=0.6061, 500=0.5742 (USD); Pricing 2: 1=0.83, 10=0.734, 50=0.685, 100=0.606, 500=0.574, 1000=0.435 (USD); Ratings: AEC-Q200; REACH SVHC: No SVHC; Stock 1: 2398; Stock 2: 4856; Supplier 1: Digi-Key; Supplier 2: Mouser; Supplier Part Number 1: 887-1656-1-ND; Supplier Part Number 2: 717-AA-12.000MAGE-T; Termination: SMD/SMT; Tolerance: 30ppm; Width: 3.2mm; Library Name: flatsat_platform.SchLib
